# Altium schematic sheet ad7768.SchDoc(ad7768)
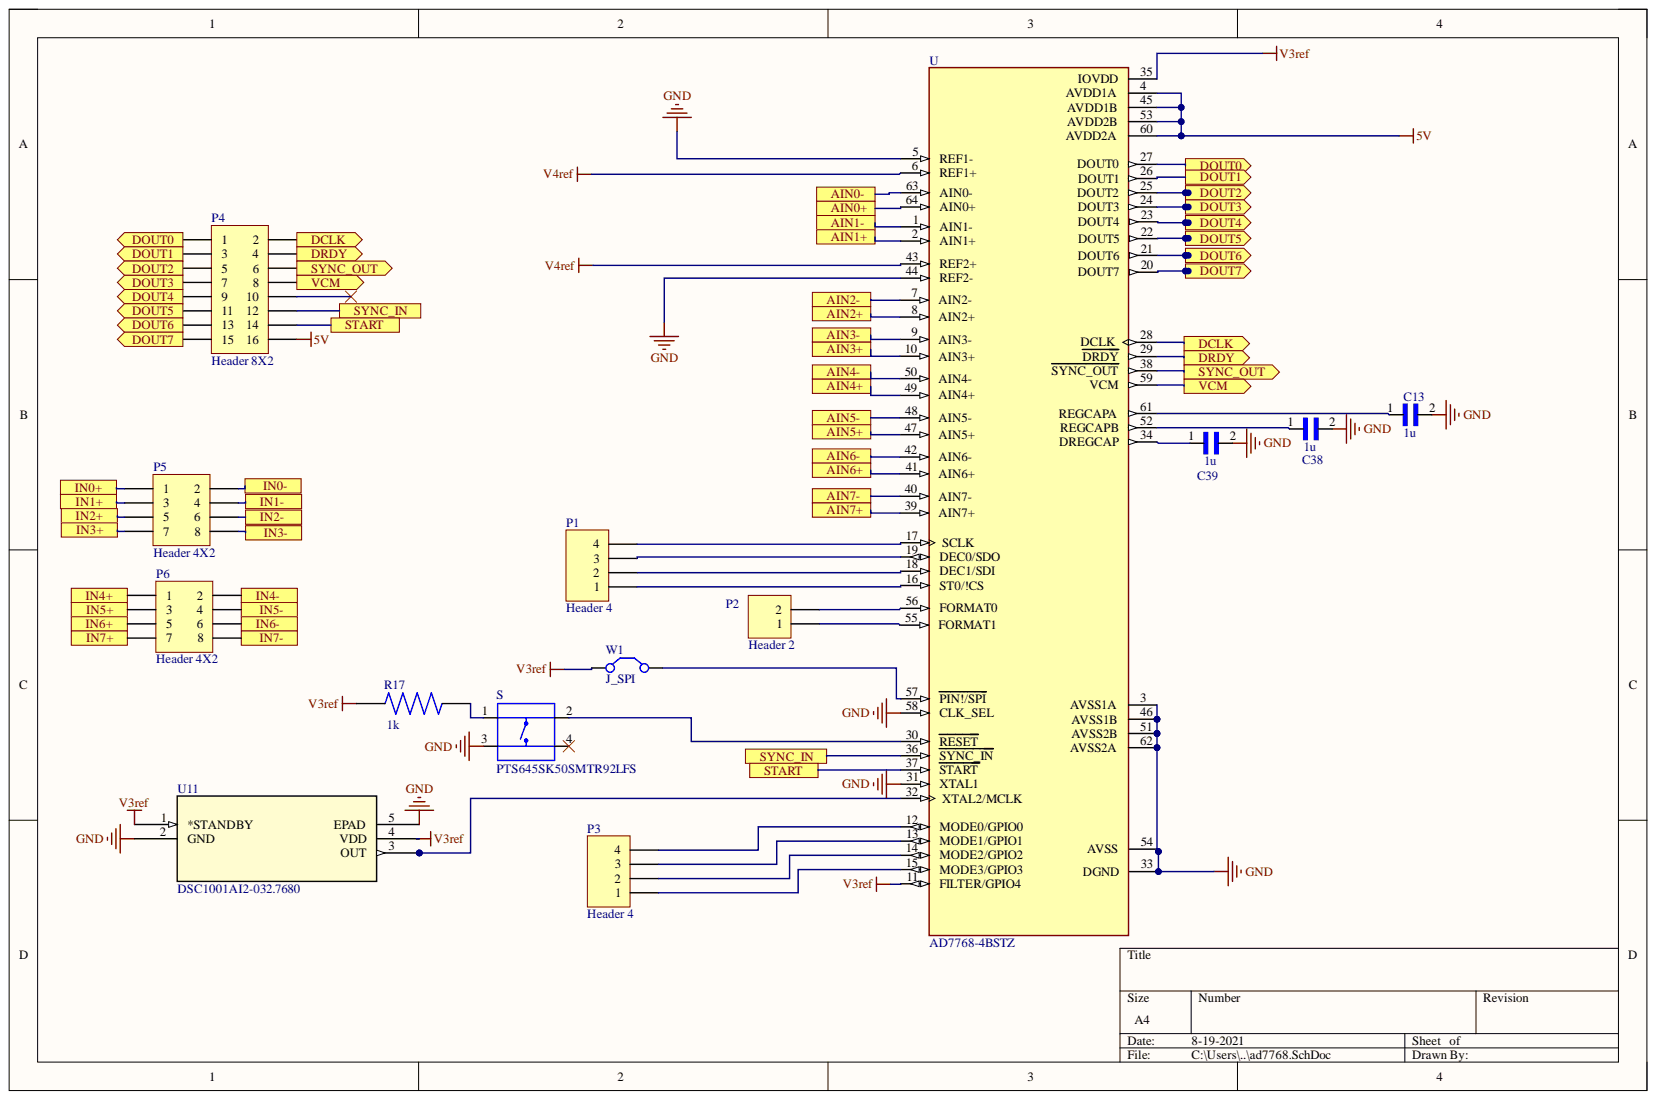
[diagram p0: ad7768.SchDoc]

## Parts
C13  1u  [CAPC3216X127N]
C38  1u  [CAPC3216X127N]
C39  1u  [CAPC3216X127N]
P1  Header 4  [HDR1X4]
P2  Header 2  [HDR1X2]
P3  Header 4  [HDR1X4]
P4  Header 8X2  [HDR2X8]
P5  Header 4X2  [HDR2X4]
P6  Header 4X2  [HDR2X4]
R17  1k  [RESC3216X55N]
S  PTS645SK50SMTR92LFS  [SW_PTS645SK50SMTR92LFS]
U  AD7768-4BSTZ  [QFP50P1200X1200X160-64N]
U11  DSC1001AI2-032.7680  [DFN4_7X5_MCH]
W1  J_SPI  [RAD-0.2]

## Ports
AIN0+, AIN0-, AIN1+, AIN1-, AIN2+, AIN2-, AIN3+, AIN3-, AIN4+, AIN4-, AIN5+, AIN5-, AIN6+, AIN6-, AIN7+, AIN7-, DCLK, DOUT0, DOUT1, DOUT2, DOUT3, DOUT4, DOUT5, DOUT6, DOUT7, DRDY, IN0+, IN0-, IN1+, IN1-, IN2+, IN2-, IN3+, IN3-, IN4+, IN4-, IN5+, IN5-, IN6+, IN6-, IN7+, IN7-, START, SYNC_IN, SYNC_OUT, VCM

## Nets
5V: P4.16 U.4(AVDD1A) U.45(AVDD1B) U.53(AVDD2B) U.60(AVDD2A)
GND: C13.2 C38.2 C39.2 S.3 U.3(AVSS1A) U.5(REF1-) U.31(XTAL1) U.33(DGND) U.44(REF2-) U.46(AVSS1B) U.51(AVSS2B) U.54(AVSS) U.58(CLK_SEL) U.62(AVSS2A) U11.2(GND) U11.5(EPAD)
V3ref: R17.1 U.11(FILTER/GPIO4) U.35(IOVDD) U11.1(*STANDBY) U11.4(VDD) W1.1
V4ref: U.6(REF1+) U.43(REF2+)
AIN0+: U.64(AIN0+) ; port AIN0+
AIN0-: U.63(AIN0-) ; port AIN0-
AIN1+: U.2(AIN1+) ; port AIN1+
AIN1-: U.1(AIN1-) ; port AIN1-
AIN2+: U.8(AIN2+) ; port AIN2+
AIN2-: U.7(AIN2-) ; port AIN2-
AIN3+: U.10(AIN3+) ; port AIN3+
AIN3-: U.9(AIN3-) ; port AIN3-
AIN4+: U.49(AIN4+) ; port AIN4+
AIN4-: U.50(AIN4-) ; port AIN4-
AIN5+: U.47(AIN5+) ; port AIN5+
AIN5-: U.48(AIN5-) ; port AIN5-
AIN6+: U.41(AIN6+) ; port AIN6+
AIN6-: U.42(AIN6-) ; port AIN6-
AIN7+: U.39(AIN7+) ; port AIN7+
AIN7-: U.40(AIN7-) ; port AIN7-
DCLK: P4.2 U.28(DCLK) ; port DCLK
DOUT0: P4.1 U.27(DOUT0) ; port DOUT0
DOUT1: P4.3 U.26(DOUT1) ; port DOUT1
DOUT2: P4.5 U.25(DOUT2) ; port DOUT2
DOUT3: P4.7 U.24(DOUT3) ; port DOUT3
DOUT4: P4.9 U.23(DOUT4) ; port DOUT4
DOUT5: P4.11 U.22(DOUT5) ; port DOUT5
DOUT6: P4.13 U.21(DOUT6) ; port DOUT6
DOUT7: P4.15 U.20(DOUT7) ; port DOUT7
DRDY: P4.4 U.29(DRDY) ; port DRDY
IN0+: P5.1 ; port IN0+
IN0-: P5.2 ; port IN0-
IN1+: P5.3 ; port IN1+
IN1-: P5.4 ; port IN1-
IN2+: P5.5 ; port IN2+
IN2-: P5.6 ; port IN2-
IN3+: P5.7 ; port IN3+
IN3-: P5.8 ; port IN3-
IN4+: P6.1 ; port IN4+
IN4-: P6.2 ; port IN4-
IN5+: P6.3 ; port IN5+
IN5-: P6.4 ; port IN5-
IN6+: P6.5 ; port IN6+
IN6-: P6.6 ; port IN6-
IN7+: P6.7 ; port IN7+
IN7-: P6.8 ; port IN7-
START: P4.14 U.37(START) ; port START
SYNC_IN: P4.12 U.36(SYNC_IN) ; port SYNC_IN
SYNC_OUT: P4.6 U.38(SYNC_OUT) ; port SYNC_OUT
VCM: P4.8 U.59(VCM) ; port VCM
NetC13_1: C13.1 U.61(REGCAPA)
NetC38_1: C38.1 U.52(REGCAPB)
NetC39_1: C39.1 U.34(DREGCAP)
NetP1_1: P1.1 U.16(ST0/!CS)
NetP1_2: P1.2 U.18(DEC1/SDI)
NetP1_3: P1.3 U.19(DEC0/SDO)
NetP1_4: P1.4 U.17(SCLK)
NetP2_1: P2.1 U.55(FORMAT1)
NetP2_2: P2.2 U.56(FORMAT0)
NetP3_1: P3.1 U.15(MODE3/GPIO3)
NetP3_2: P3.2 U.14(MODE2/GPIO2)
NetP3_3: P3.3 U.13(MODE1/GPIO1)
NetP3_4: P3.4 U.12(MODE0/GPIO0)
NetR17_2: R17.2 S.1
NetS_2: S.2 U.30(RESET)
NetU11_3: U.32(XTAL2/MCLK) U11.3(OUT)
NetU_57: U.57(PIN!/SPI) W1.2

Not connected: P4 10; S 4

## Library data of the parts
C13 C38 C39 = 1206YC105KAT2A: Cap Ceramic 1uF 16V X7R 10% SMD 1206 125ÂÂŽ T/R; MF: {'manufacturer': u'AVX'}; Availability: Unavailable; Component Kind: Standard; Library Name: 1206YC105KAT2A.SchLib; MP: 1206YC105KAT2A; Package: None; Price: None
P1 P3 = Header 4: Header, 4-Pin; Component Kind: Standard; LatestRevisionDate: 17-Jul-2002; LatestRevisionNote: Re-released for DXP Platform.; Library Name: Miscellaneous Connectors.IntLib; Signal Integrity: Connector; Publisher: Altium Limited
P2 = Header 2: Header, 2-Pin; Component Kind: Standard; LatestRevisionDate: 17-Jul-2002; LatestRevisionNote: Re-released for DXP Platform.; Library Name: Miscellaneous Connectors.IntLib; Signal Integrity: Connector; Publisher: Altium Limited
P4 = Header 8X2: Header, 8-Pin, Dual row; Component Kind: Standard; LatestRevisionDate: 17-Jul-2002; LatestRevisionNote: Re-released for DXP Platform.; Library Name: Miscellaneous Connectors.IntLib; Signal Integrity: Connector; Publisher: Altium Limited
P5 P6 = Header 4X2: Header, 4-Pin, Dual row; Component Kind: Standard; LatestRevisionDate: 17-Jul-2002; LatestRevisionNote: Re-released for DXP Platform.; Library Name: Miscellaneous Connectors.IntLib; Signal Integrity: Connector; Publisher: Altium Limited
R17 = HRG3216P-1001-B-T1: RES SMD 1K OHM 0.1% 1W 1206; MF: {'manufacturer': u'Susumu'}; Availability: Unavailable; Component Kind: Standard; MP: HRG3216P-1001-B-T1; Package: None; Price: None
S = PTS645SK50SMTR92LFS: Tactile Switch SPST-NO Top Actuated Surface Mount; MF: C&K; Availability: Unavailable; Component Kind: Standard; Library Name: switch.SchLib; MP: PTS645SK50SMTR92LFS; Package: None; Price: None; SnapEDA_Link: https://www.snapeda.com/parts/PTS645SK50SMTR92LFS/C%26K/view-part/226517/?ref=snap
U = AD7768-4BSTZ: 24 Bit Analog to Digital Converter 4 Input 4 Sigma-Delta 64-LQFP _10x10_; MF: Analog Devices; Component Kind: Standard; Digi-Key_Part_Number: AD7768-4BSTZ-ND; Digi-Key_Purchase_URL: https://www.digikey.com/product-detail/en/analog-devices-inc/AD7768-4BSTZ/AD7768-4BSTZ-ND/6072260?utm_source=snapeda&utm_medium=aggregator&utm_campaign=symbol; MP: AD7768-4BSTZ; Package: LQFP -64 Analog Devices; SnapEDA_Link: https://www.snapeda.com/parts/AD7768-4BSTZ/Analog%20Devices%20Inc./view-part/501603/?ref=snap; Library Name: ad7768_testboard.SCHLIB
U11 = DSC1001AI2-032.7680: No Description Available; Mfr_Name: Microchip; Component Kind: Standard; Digi-Key_Part_Number_1: DSC1001AI2-032.7680-ND; Library Name: DSC1001AI2-032P7680.SchLib; Manufacturer_Part_Number: DSC1001AI2-032.7680; RefDes: RefDes; Type: DEV; Copyright: Copyright (C) 2021 Ultra Librarian. All rights reserved.
W1 = Jumper: Jumper Wire; Component Kind: Standard; LatestRevisionDate: 17-Jul-2002; LatestRevisionNote: Re-released for DXP Platform.; Library Name: Miscellaneous Devices.IntLib; PackageDescription: Radial Cap, Thru-Hole; 2 Leads; 0.2 in Pin Spacing; PackageReference: RAD-0.2; Published: 24-Mar-1999; Publisher: Altium Limited
